# Altium schematic sheet Power Circuit.SchDoc("POWER SUPPLY")
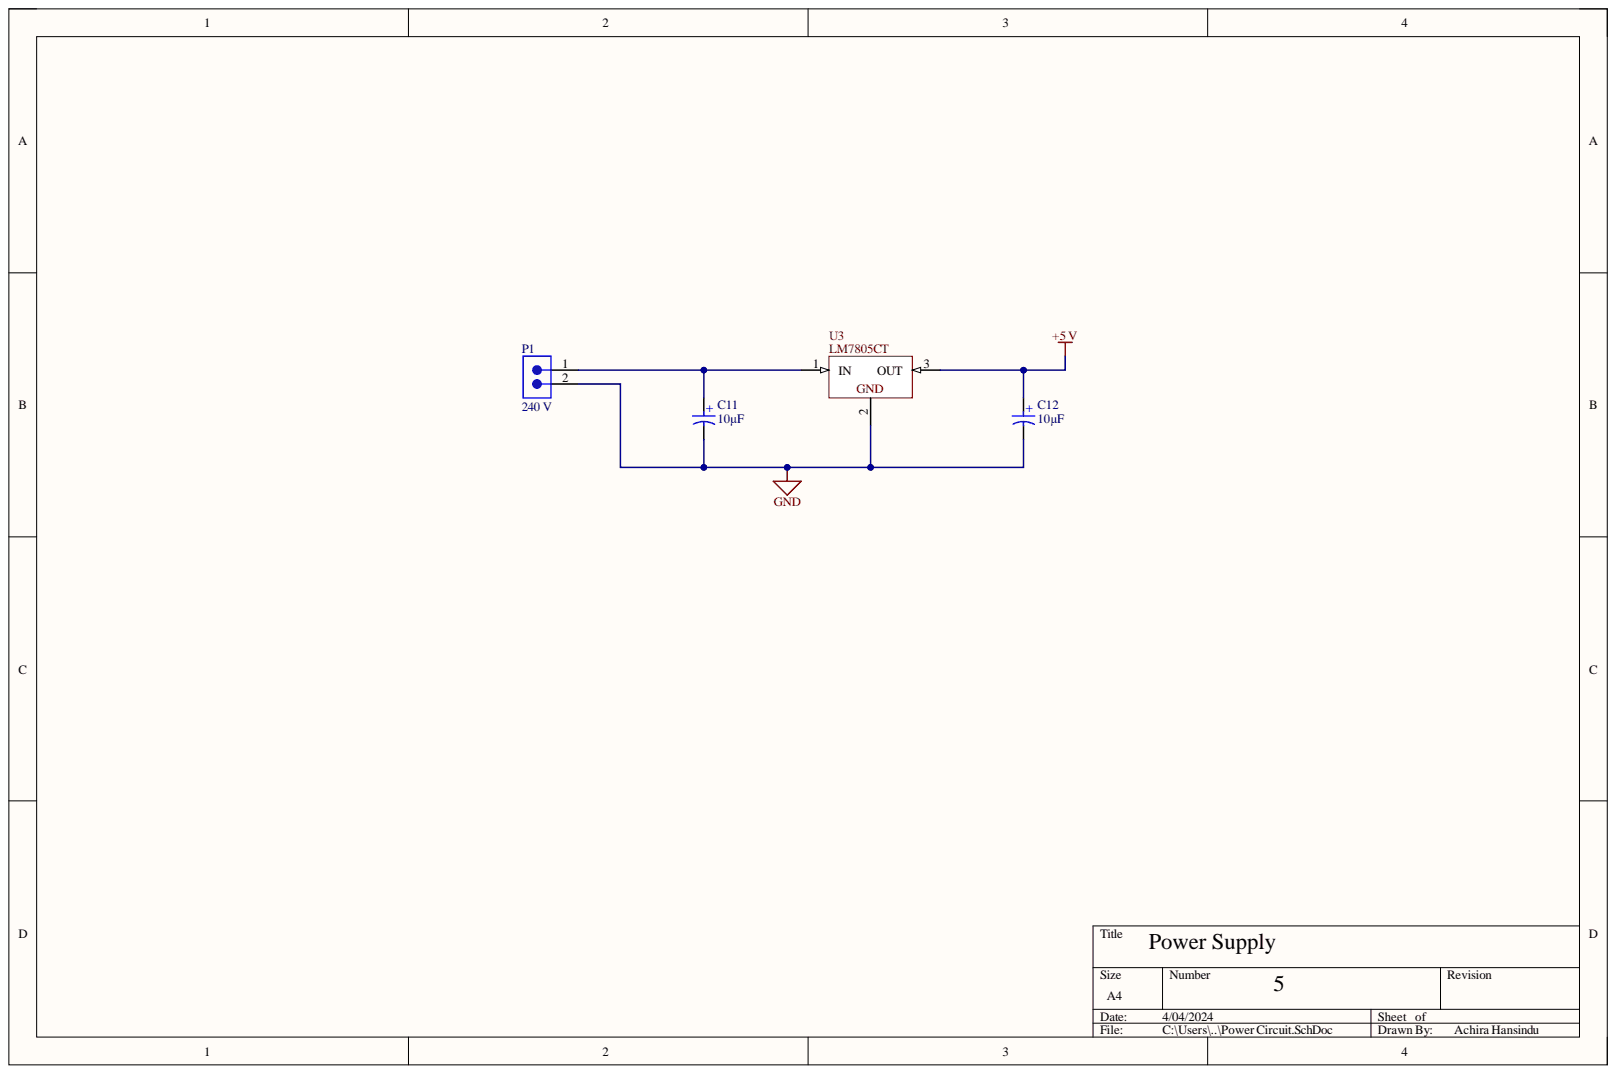
[diagram p0: Power Circuit.SchDoc]

## Parts
C11  Capacitance: 10µF  [WCAP-HTG5_D6.3H8]  (hidden comment: 870575873001)
C12  Capacitance: 10µF  [WCAP-HTG5_D6.3H8]  (hidden comment: 870575873001)
P1  240 V  [691322110002]
U3  LM7805CT  [FAIR-TO-220-3]

## Nets
+5 V: C12.1 U3.3
GND: C11.2 C12.2 P1.2 U3.2
NetC11_1: C11.1 P1.1 U3.1

## Library data of the parts
C11 C12 = CMP-03913-134709123-1: 870575873001 Cap, 10Uf, 63V, Alu Elec, Hybrid, Rad Rohs Compliant: Yes; ESR (Equivalent Series Resistance): 100mΩ; Hole Diameter: 900µm; Leakage Current: 6.3µA; Pitch: 2.5mm; Ripple Current: 1.06A; Component Kind: Standard; Diameter: 6.3mm; Length: 8mm; Library Name: Altium Content Vault; Max Operating Temperature: 105°C; Min Operating Temperature: -55°C; NexarPartId: 134709123; RoHS: Compliant; Voltage Rating: 63V
P1 = CMP-1502-01791-3: NexarPartId: 18818346; Current: 10A; Gender: Male; Number of Contacts: 2; Number of Levels: 1; Pitch: 3.5052mm; Voltage: 300V; Color: Green; Component Kind: Standard; Contact Material: Brass; Current Rating: 10A; Height: 7.4mm; Lead Free: Lead Free; Lead Pitch: 3.5mm; Length: 10.5mm; Library Name: Altium Content Vault; Max Operating Temperature: 105°C; Min Operating Temperature: -40°C; Mount: Through Hole; Number of Pins: 2; Number of Positions Per Level: 2; Number of Rows: 1; Packaging: Box; REACH SVHC: Unknown; RoHS: Compliant; Termination: Solder; Voltage Rating: 300V; Width: 8.1mm
U3 = CMP-2000-04938-1: Positive Voltage Regulator, 5 V, 1 A, -40 to 125 degC, 3-Pin TO-220, RoHS, Tube; Manufacturer: FairchildSemiconductor; Accuracy: ±4%; Line Regulation: 100mV; Maximum Input Voltage: 35V; Maximum Output Current: 2.2(Typ)A; Maximum Quiescent Current: 8mA; Number of Outputs: 1; Output Type: Fixed; Output Voltage: 5V; Rad Hard: No; Typical Dropout Voltage @ Current: 2@1AV; Category: LinearRegulator; Component Kind: Standard; Datasheet URL: https://datasheet.ciiva.com/26904/lm7805ct-26904988.pdf; Datasheet Version: Aug-12; Library Name: Altium Content Vault; Load Regulation: 100mV; Manufacturer URL: https://www.fairchildsemi.com/; Mounting: ThroughHole; Operating Temperature: -40to125degC; Package: 3TO-220AB; Package Description: 3-Pin Through Hole Device, Body 10 x 4.5 mm, Pitch 2.54 mm; Package Reference: TO-220; Package URL: https://www.fairchildsemi.com/package-drawings/TO/TO220B03.pdf; Packaging: Rail/Tube; Polarity: Positive; Type: Linear
